# Altium schematic sheet 06_STARNOTE-CONNECTION.SchDoc
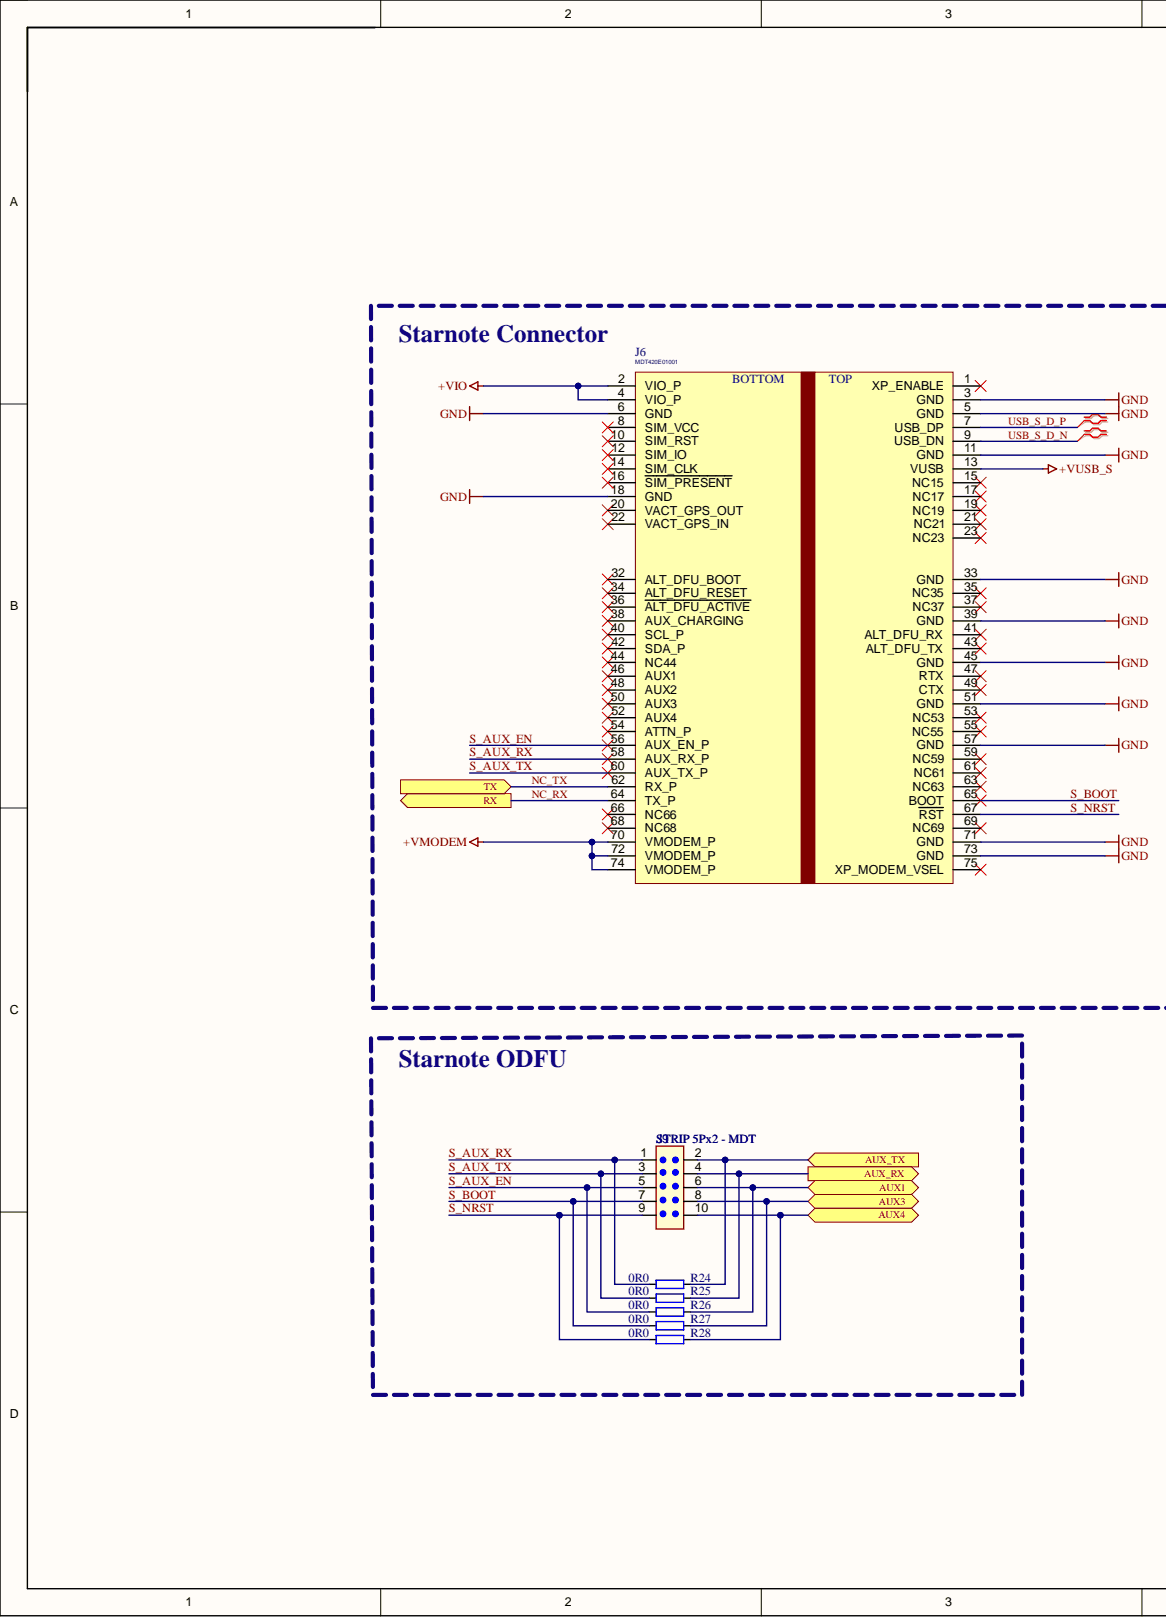
[diagram p0: 06_STARNOTE-CONNECTION.SchDoc, left]
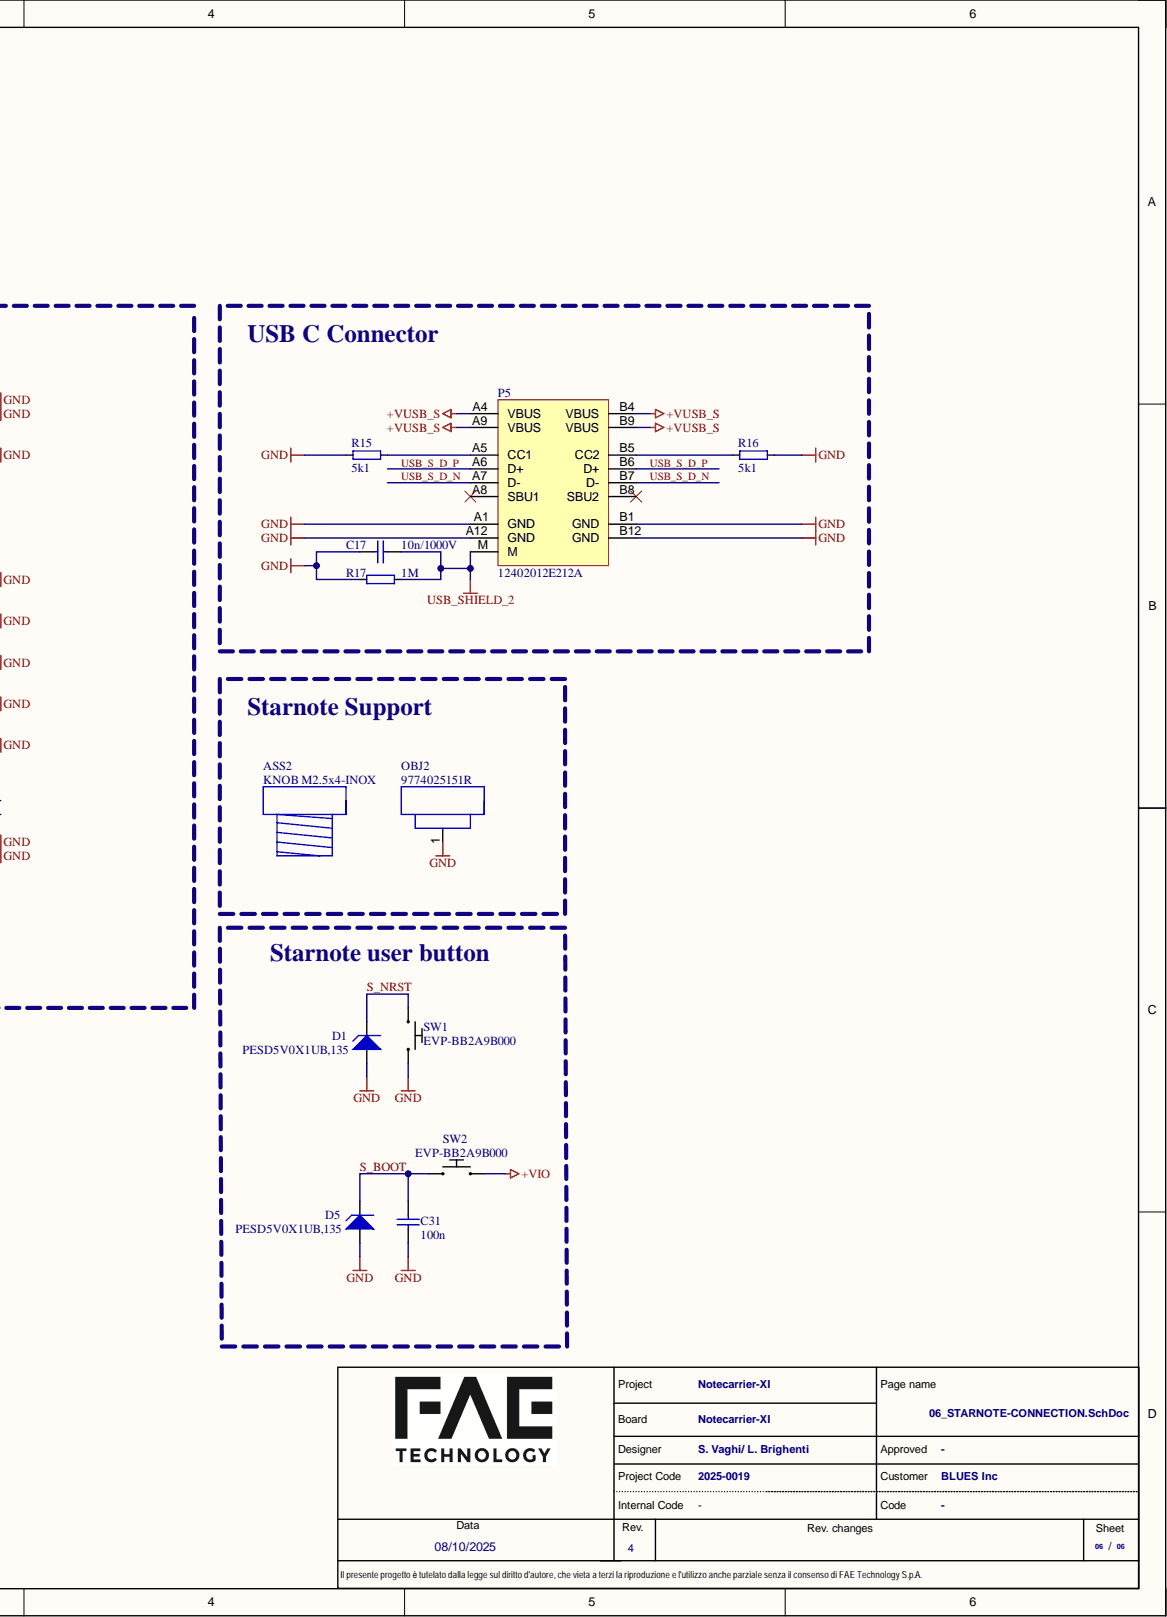
[diagram p1: 06_STARNOTE-CONNECTION.SchDoc, right]

## Parts
ASS2  KNOB M2.5x4-INOX  (mechanical)
C17  10n/1000V  [C-1206]
C31  100n  [C-0402]
D1  PESD5V0X1UB,135  [SOD-523F]
D5  PESD5V0X1UB,135  [SOD-523F]
J6  MDT420E01001  [J-75-0050-MOS-M2 - STARNOTEIV3]
J9  STRIP 5Px2 - MDT  Modello: STRIP  [J-5X2-0254-MDT]
OBJ2  9774025151R  [DIST-WASMSIM0250]
P5  12402012E212A  [CONN_12402012E212A]
R15  5k1  [R-0402]
R16  5k1  [R-0402]
R17  1M  [R-1206]
R24  0R0  [R-0603]
R25  0R0  [R-0603]
R26  0R0  [R-0603]
R27  0R0  [R-0603]
R28  0R0  [R-0603]
SW1  EVP-BB2A9B000  [SW_EVP-BB2A9B000]
SW2  EVP-BB2A9B000  [SW_EVP-BB2A9B000]

## Ports
AUX1, AUX3, AUX4, AUX_RX, AUX_TX, RX, TX

## Nets
+VIO: J6.2(VIO_P) J6.4(VIO_P) SW2.2
+VMODEM: J6.70(VMODEM_P) J6.72(VMODEM_P) J6.74(VMODEM_P)
+VUSB_S: J6.13(VUSB) P5.A4(VBUS) P5.A9(VBUS) P5.B4(VBUS) P5.B9(VBUS)
GND: C17.2 C31.2 D1.1 D5.1 J6.3(GND) J6.5(GND) J6.6(GND) J6.11(GND) J6.18(GND) J6.33(GND) J6.39(GND) J6.45(GND) J6.51(GND) J6.57(GND) J6.71(GND) J6.73(GND) OBJ2.1 P5.A1(GND) P5.A12(GND) P5.B1(GND) P5.B12(GND) R15.1 R16.2 R17.2 SW1.2
USB_SHIELD_2: C17.1 P5.M R17.1
AUX1: J9.6 R26.2 ; port AUX1
AUX3: J9.8 R27.2 ; port AUX3
AUX4: J9.10 R28.2 ; port AUX4
AUX_RX: J9.4 R25.2 ; port AUX_RX
AUX_TX: J9.2 R24.2 ; port AUX_TX
NC_RX: J6.64(TX_P) ; port RX
NC_TX: J6.62(RX_P) ; port TX
S_AUX_EN: J6.56(AUX_EN_P) J9.5 R26.1
S_AUX_RX: J6.58(AUX_RX_P) J9.1 R24.1
S_AUX_TX: J6.60(AUX_TX_P) J9.3 R25.1
S_BOOT: C31.1 D5.2 J6.65(BOOT) J9.7 R27.1 SW2.1
S_NRST: D1.2 J6.67(RST) J9.9 R28.1 SW1.1
USB_S_D_N: J6.9(USB_DN) P5.A7(D-) P5.B7(D-)
USB_S_D_P: J6.7(USB_DP) P5.A6(D+) P5.B6(D+)
NetP5_A5: P5.A5(CC1) R15.2
NetP5_B5: P5.B5(CC2) R16.1

Not connected: J6 1(XP_ENABLE) 8(SIM_VCC) 10(SIM_RST) 12(SIM_IO) 14(SIM_CLK) 15(NC15) 16(SIM_PRESENT) 17(NC17) 19(NC19) 20(VACT_GPS_OUT) 21(NC21) 22(VACT_GPS_IN) 23(NC23) 32(ALT_DFU_BOOT) 34(ALT_DFU_RESET) 35(NC35) 36(ALT_DFU_ACTIVE) 37(NC37) 38(AUX_CHARGING) 40(SCL_P) 41(ALT_DFU_RX) 42(SDA_P) 43(ALT_DFU_TX) 44(NC44) 46(AUX1) 47(RTX) 48(AUX2) 49(CTX) 50(AUX3) 52(AUX4) 53(NC53) 54(ATTN_P) 55(NC55) 59(NC59) 61(NC61) 63(NC63) 66(NC66) 68(NC68) 69(NC69) 75(XP_MODEM_VSEL); P5 A8(SBU1) B8(SBU2)

## Library data of the parts
ASS2 = KNOB - M2.5x4-INOX: VITE TCEI 2.5X4 ESAGONO INCASSATO A2 INOX; BL Part Number: 6000-168; Manufacturer: KRAFTBERG; Manufacturer Part Number: M2.5X4/D7985-A2; Drawn_By: Blues Wireless; Library Name: n21-p2.SchLib; Supplier 1: TME; Supplier Part Number 1: M2.5X4/D7985-A2
C17 = C1206-10n-1000V-X7R - CS1206KKX7RCBB103: Capacitor ceramic 10n ±10% X7R 1000V 1206; BL Part Number: 2007-449; Manufacturer: YAGEO; Checked_By: Marsic; Drawn_By: Hamin; Component Kind: Standard; DateModified: 03-05-2023; Library Name: BL_Passive.SchLib; Manufacturer Part Number: CS1206KKX7RCBB103; Supplier 1: Digi-Key; Supplier Part Number 1: 311-3578-1-ND
C31 = C0402-100n-16V-X7R: Capacitor ceramic 100n ±10% X7R 16V 0402; BL Part Number: 2000-908; Manufacturer: Murata Electronics; Manufacturer Part Number: GRM155R71C104KA88D; Checked_By: Dodig; Drawn_By: Puskar; Pkg Type: SMD; Component Kind: Standard; DateModified: 27-08-2013; Package: 0402; Simulation: CAP; Supplier 1: Digi-Key; Supplier Part Number 1: 490-3261-1-ND; Library Name: 100554_notecarrier-xsi.SCHLIB
D1 D5 = PESD5V0X1UB,135: TVS DIODE 5VWM 8VC SOD523; BL Part Number: 2004-110; Manufacturer: Nexperia; Checked_By: Hamin; Drawn_By: Zvonc; Component Kind: Standard; DateModified: 17-10-2018; Library Name: BL_Active.SchLib; Manufacturer Part Number: PESD5V0X1UB,135; Supplier 1: Digi-Key; Supplier Part Number 1: 1727-5906-1-ND
J6 = STARNOTEI-V3: CONN. M.2 75P M/90° P=0.5 MDT420E01001 AMPHENOL SMT; BL Part Number: 2007-460; Manufacturer: Amphenol ICC (FCI); Manufacturer Part Number: MDT420E03001; Drawn_By: Blues Wireless; Component Kind: Standard; Supplier 1: Digi-Key; Supplier Part Number 1: MDT420E03001CT-ND; Library Name: 100554_notecarrier-xsi.SCHLIB
J9 = STRIP-J-5X2-0254-MDT: STRIP 2P M/D DOPPIA FILA P=2.54 DORATO CH81802V100; Manufacturer: Samtec; MPN: TSW-105-07-F-D; Caratteristiche: 5x2P; Database: 01688; Famiglia: CONNETTORE; Pkg Type: THT; Component Kind: Standard; Distributor: FAE; Price Distributor Cad: 0,002; Value: STRIP 5Px2 - MDT; Library Name: CONNETTORI - FAE_Library.SchLib
OBJ2 = DISTANZIALE - M2.5x0250: DISTANZ. CIL. METALLO 5.1X2.5 9774025151R W.E SMT; BL Part Number: 6020-113; Manufacturer: Würth Elektronik; Drawn_By: Blues Wieless; Component Kind: Standard; Library Name: n21-p2.SchLib; Manufacturer Part Number: 9774025151R; Supplier 1: Digi-Key; Supplier Part Number 1: 732-7078-1-ND
P5 = Conn USB C 12402012E212A: CONN RCP USB2.0 TYP C 24P SMD RA; BL Part Number: 2008-437; Manufacturer: Amphenol; Checked_By: Hlupic; Drawn_By: Anic-Ivicic; Component Kind: Standard; DateModified: 19-03-2024; Library Name: BL_Mechanical.SchLib; Manufacturer Part Number: 12402012E212A; Supplier 1: Digi-Key; Supplier Part Number 1: 664-12402012E212ATR-ND
R15 R16 = R0402-5k1-1: Resistor 5k1 ±1% 0402; BL Part Number: 2004-098; Manufacturer: YAGEO; Manufacturer Part Number: RC0402FR-075K1L; Checked_By: Hamin; Drawn_By: PuskarJ; Pkg Type: SMD; Component Kind: Standard; DateModified: 21-09-2018; Package: 0402; Supplier 1: Digi-Key; Supplier Part Number 1: P5.10KLCT-ND; Library Name: 100554_notecarrier-xsi.SCHLIB
R17 = R1206-1M-1: Resistor 1M ±1% 1206; BL Part Number: 2001-438; Manufacturer: TE Connectivity Passive Product; Manufacturer Part Number: CRG1206F1M0; Checked_By: Golubic; Drawn_By: Zvonc; Pkg Type: SMD; Component Kind: Standard; DateModified: 01-07-2014; Package: 1206; Library Name: 100554_notecarrier-xsi.SCHLIB
R24-R28 = R0603-0R0: Resistor 0R0 0603; BL Part Number: 2000-723; Manufacturer: YAGEO; Manufacturer Part Number: RC0603JR-070RL; Checked_By: Puskar; Drawn_By: Dodig; Pkg Type: SMD; Component Kind: Standard; DateModified: 07-12-2013; Package: 0603; Library Name: 100554_notecarrier-xsi.SCHLIB
SW1 SW2 = Switch tact EVP-BB2A9B000: SWITCH TACTILE SPST-NO 0.05A 15V; BL Part Number: 2007-124; Manufacturer: Panasonic Electronic Components; Drawn_By: Marsic; Component Kind: Standard; DateModified: 09-02-2023; Library Name: BL_Mechanical.SchLib; Manufacturer Part Number: EVP-BB2A9B000
